# Altium schematic sheet 09-STM32-02.SchDoc(09-STM32-02)
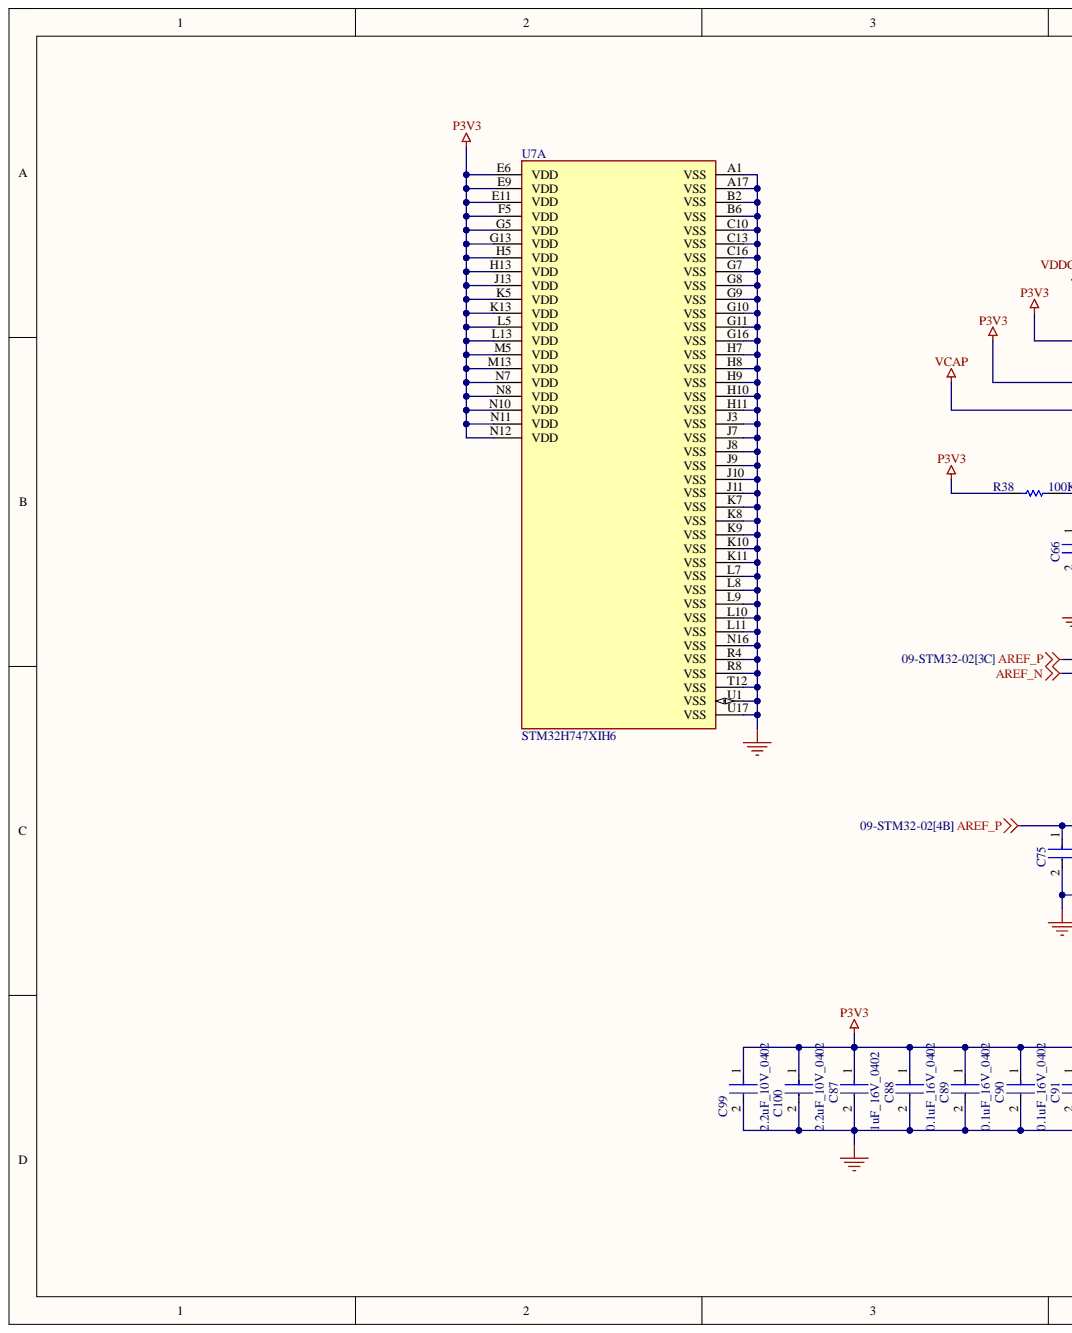
[diagram p0: 09-STM32-02.SchDoc, left]
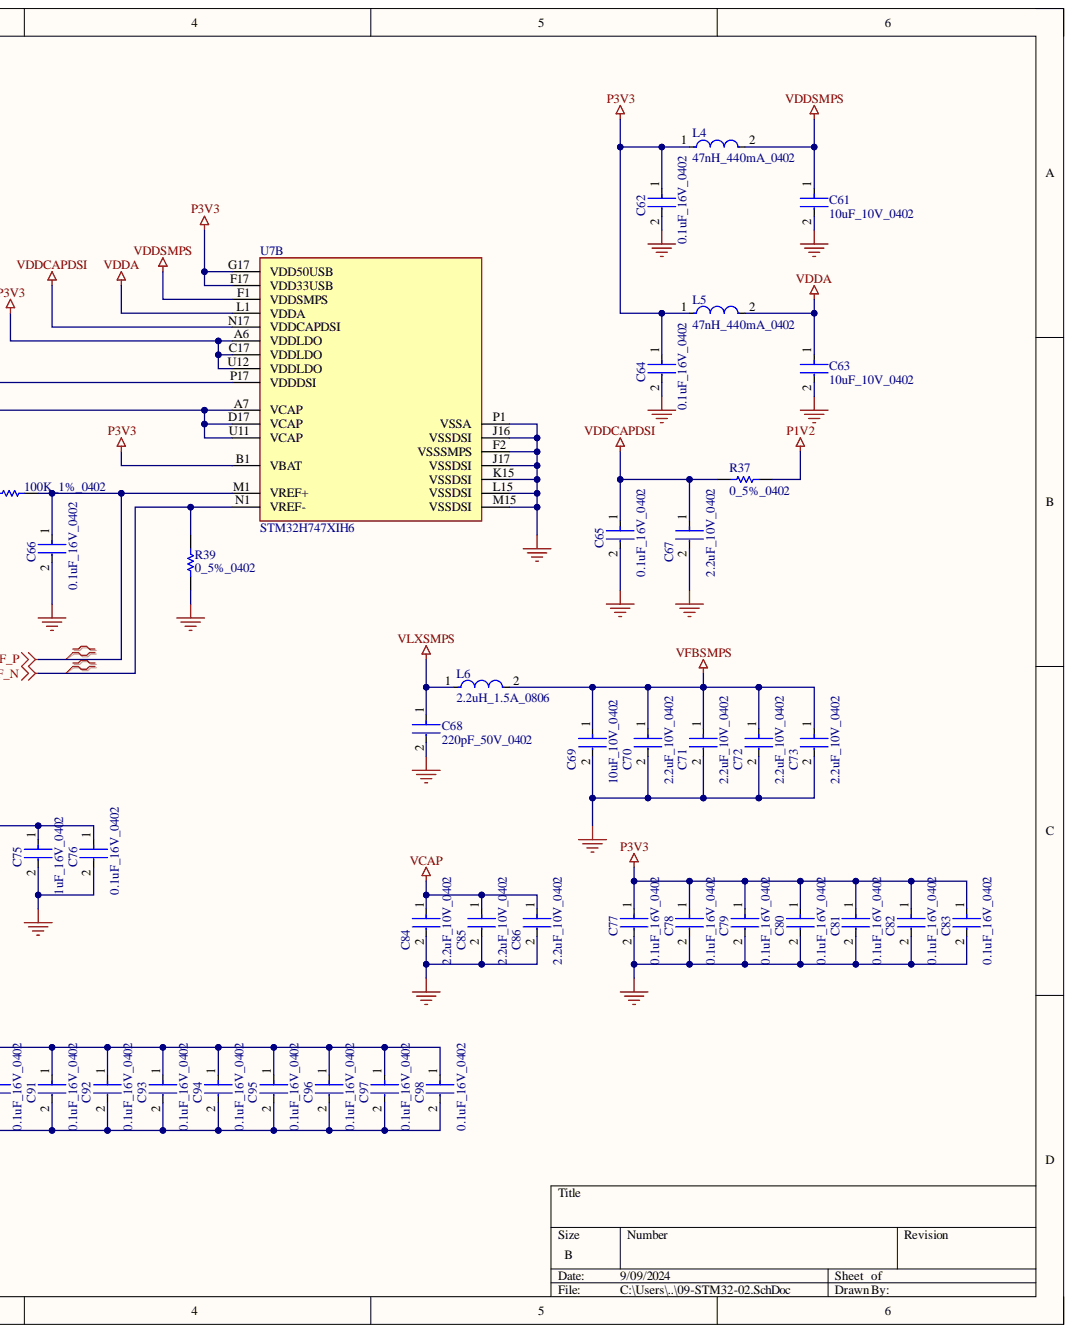
[diagram p1: 09-STM32-02.SchDoc, right]

## Parts
C61  10uF_10V_0402  [FP-CL05A106MP5NUNC-MFG]
C62  0.1uF_16V_0402  [FP-C0402-BB-MFG]
C63  10uF_10V_0402  [FP-CL05A106MP5NUNC-MFG]
C64  0.1uF_16V_0402  [FP-C0402-BB-MFG]
C65  0.1uF_16V_0402  [FP-C0402-BB-MFG]
C66  0.1uF_16V_0402  [FP-C0402-BB-MFG]
C67  2.2uF_10V_0402  [FP-C1005-050-0_15-0_1-MFG]
C68  220pF_50V_0402  [FP-GCM155-0_05-IPC_C]
C69  10uF_10V_0402  [FP-CL05A106MP5NUNC-MFG]
C70  2.2uF_10V_0402  [FP-C1005-050-0_15-0_1-MFG]
C71  2.2uF_10V_0402  [FP-C1005-050-0_15-0_1-MFG]
C72  2.2uF_10V_0402  [FP-C1005-050-0_15-0_1-MFG]
C73  2.2uF_10V_0402  [FP-C1005-050-0_15-0_1-MFG]
C75  1uF_16V_0402  [FP-0402-L_1_0_0_05-W_0_5-IPC_A]
C76  0.1uF_16V_0402  [FP-C0402-BB-MFG]
C77  0.1uF_16V_0402  [FP-C0402-BB-MFG]
C78  0.1uF_16V_0402  [FP-C0402-BB-MFG]
C79  0.1uF_16V_0402  [FP-C0402-BB-MFG]
C80  0.1uF_16V_0402  [FP-C0402-BB-MFG]
C81  0.1uF_16V_0402  [FP-C0402-BB-MFG]
C82  0.1uF_16V_0402  [FP-C0402-BB-MFG]
C83  0.1uF_16V_0402  [FP-C0402-BB-MFG]
C84  2.2uF_10V_0402  [FP-C1005-050-0_15-0_1-MFG]
C85  2.2uF_10V_0402  [FP-C1005-050-0_15-0_1-MFG]
C86  2.2uF_10V_0402  [FP-C1005-050-0_15-0_1-MFG]
C87  1uF_16V_0402  [FP-0402-L_1_0_0_05-W_0_5-IPC_A]
C88  0.1uF_16V_0402  [FP-C0402-BB-MFG]
C89  0.1uF_16V_0402  [FP-C0402-BB-MFG]
C90  0.1uF_16V_0402  [FP-C0402-BB-MFG]
C91  0.1uF_16V_0402  [FP-C0402-BB-MFG]
C92  0.1uF_16V_0402  [FP-C0402-BB-MFG]
C93  0.1uF_16V_0402  [FP-C0402-BB-MFG]
C94  0.1uF_16V_0402  [FP-C0402-BB-MFG]
C95  0.1uF_16V_0402  [FP-C0402-BB-MFG]
C96  0.1uF_16V_0402  [FP-C0402-BB-MFG]
C97  0.1uF_16V_0402  [FP-C0402-BB-MFG]
C98  0.1uF_16V_0402  [FP-C0402-BB-MFG]
C99  2.2uF_10V_0402  [FP-C1005-050-0_15-0_1-MFG]
C100  2.2uF_10V_0402  [FP-C1005-050-0_15-0_1-MFG]
L4  47nH_440mA_0402  [FP-LQW15AN_80-MFG]
L5  47nH_440mA_0402  [FP-LQW15AN_80-MFG]
L6  2.2uH_1.5A_0806  [FP-DFE201612C-MFG]
R37  0_5%_0402  [RESC1005X40X25NL05T10]
R38  100K_1%_0402  [RESC1005X40X25ML05T10]
R39  0_5%_0402  [RESC1005X40X25NL05T10]
U7  STM32H747XIH6  [FP-TFBGA240+25-A07U-MFG]  (parts A,B)

## Nets
AREF_N: R39.2 U7.N1(VREF-)
AREF_P: C66.1 C75.1 C76.1 R38.2 U7.M1(VREF+)
GND: C61.2 C62.2 C63.2 C64.2 C65.2 C66.2 C67.2 C68.2 C69.2 C70.2 C71.2 C72.2 C73.2 C75.2 C76.2 C77.2 C78.2 C79.2 C80.2 C81.2 C82.2 C83.2 C84.2 C85.2 C86.2 C87.2 C88.2 C89.2 C90.2 C91.2 C92.2 C93.2 C94.2 C95.2 C96.2 C97.2 C98.2 C99.2 C100.2 R39.1 U7.A1(VSS) U7.A17(VSS) U7.B2(VSS) U7.B6(VSS) U7.C10(VSS) U7.C13(VSS) U7.C16(VSS) U7.F2(VSSSMPS) U7.G7(VSS) U7.G8(VSS) U7.G9(VSS) U7.G10(VSS) U7.G11(VSS) U7.G16(VSS) U7.H7(VSS) U7.H8(VSS) U7.H9(VSS) U7.H10(VSS) U7.H11(VSS) U7.J3(VSS) U7.J7(VSS) U7.J8(VSS) U7.J9(VSS) U7.J10(VSS) U7.J11(VSS) U7.J16(VSSDSI) U7.J17(VSSDSI) U7.K7(VSS) U7.K8(VSS) U7.K9(VSS) U7.K10(VSS) U7.K11(VSS) U7.K15(VSSDSI) U7.L7(VSS) U7.L8(VSS) U7.L9(VSS) U7.L10(VSS) U7.L11(VSS) U7.L15(VSSDSI) U7.M15(VSSDSI) U7.N16(VSS) U7.P1(VSSA) U7.R4(VSS) U7.R8(VSS) U7.T12(VSS) U7.U1(VSS) U7.U17(VSS)
P1V2: R37.1
P3V3: C62.1 C64.1 C77.1 C78.1 C79.1 C80.1 C81.1 C82.1 C83.1 C87.1 C88.1 C89.1 C90.1 C91.1 C92.1 C93.1 C94.1 C95.1 C96.1 C97.1 C98.1 C99.1 C100.1 L4.1 L5.1 R38.1 U7.A6(VDDLDO) U7.B1(VBAT) U7.C17(VDDLDO) U7.E6(VDD) U7.E9(VDD) U7.E11(VDD) U7.F5(VDD) U7.F17(VDD33USB) U7.G5(VDD) U7.G13(VDD) U7.G17(VDD50USB) U7.H5(VDD) U7.H13(VDD) U7.J13(VDD) U7.K5(VDD) U7.K13(VDD) U7.L5(VDD) U7.L13(VDD) U7.M5(VDD) U7.M13(VDD) U7.N7(VDD) U7.N8(VDD) U7.N10(VDD) U7.N11(VDD) U7.N12(VDD) U7.P17(VDDDSI) U7.U12(VDDLDO)
VCAP: C84.1 C85.1 C86.1 U7.A7(VCAP) U7.D17(VCAP) U7.U11(VCAP)
VDDA: C63.1 L5.2 U7.L1(VDDA)
VDDCAPDSI: C65.1 C67.1 R37.2 U7.N17(VDDCAPDSI)
VDDSMPS: C61.1 L4.2 U7.F1(VDDSMPS)
VFBSMPS: C69.1 C70.1 C71.1 C72.1 C73.1 L6.2
VLXSMPS: C68.1 L6.1

## Library data of the parts
C61 C63 C69 = CMP-13271-000002-1: CAP CER 10UF 10V X5R 0402; Manufacturer: Samsung; Manufacturer Part Number: CL05A106MP5NUNC; Material: Ceramic; Temperature Coefficient: 15%; Tolerance: 20%; Automotive: No; Capacitance: 10uF; Category: Cap; Component Kind: Standard; ComponentLink2Description: Datasheet; ComponentLink2URL: http://www.samsungsem.com/kr/support/product-search/mlcc/__icsFiles/afieldfile/2019/05/10/19-CL05A106MP5NUNC.pdf; Device Class L1: Passive Components; Device Class L2: Capacitors; Device Class L3: Ceramic Capacitors; Digikey Description: CAP CER 10UF 10V X5R 0402; Height: 0.7mm; Ipc Land Pattern Name: CAPC10050X50; Lead Free: Yes; Library Name: Altium Content Vault; Octopart: https://octopart.com/cl05a106mp5nunc-samsung-22457395; Package: CL05A106MP5NUNC; RoHS: TRUE; Temperature: -55°C to +85°C; Temperature Characteristic: X5R; Temperature Range High: +85°C; Temperature Range Low: -55°C; Value: 10uF; Voltage Rating: 10V
C62 C64-C66 C76-C83 C88-C98 = CMP-1034-02068-3: CAP CER 0.1UF 16V 5% X7R 0402; Manufacturer: Kemet; Manufacturer Part Number: C0402C104J4RACTU; Capacitance: 0.10 μF; Material: Ceramic; Temperature Coefficient: 15%; Tolerance: 0.05; Value: 0.10 μF; Automotive: No; Category: Cap; Component Kind: Standard; ComponentLink2Description: Datasheet; ComponentLink2URL: https://content.kemet.com/datasheets/KEM_C1002_X7R_SMD.pdf; Device Class L1: Passive Components; Device Class L2: Capacitors; Device Class L3: Ceramic Capacitors; Digikey Description: CAP CER 0.1UF 16V 5% X7R 0402; Height: 0.55mm; Ipc Land Pattern Name: CAPC10050X50; Lead Free: Yes; Library Name: Altium Content Vault; Mouser Description: CAP CER 0.1UF 16V X7R 0402; Octopart: https://octopart.com/c0402c104j4ractu-kemet-12573893; Package: C0402-BB; RoHS: TRUE; Temperature: -55°C to +125°C; Temperature Characteristic: X7R; Temperature Range High: +125°C; Temperature Range Low: -55°C; Voltage Rating: 16 V
C67 C70-C73 C84-C86 C99 C100 = CMP-08246-004344-1: CAP CER 2.2UF 10V X7S 0402; Dielectric Material: Ceramic; Tolerance: 10%; Capacitance: 2.2uF; Case Code (Imperial): 0402; Case Code (Metric): 1005; Case/Package: 0402; Component Kind: Standard; Depth: 0.5mm; Dielectric: X7S; Height: 0.5mm; Lead Free: Lead Free; Length: 1mm; Library Name: Altium Content Vault; Max Operating Temperature: 125°C; Min Operating Temperature: -55°C; Mount: Surface Mount; Package Quantity: 1; Packaging: Tape and Reel; Resistor Type: Ceramic; RoHS Compliant: Yes; Series: C; Termination: SMD/SMT; Thickness: 0.022inch; Voltage Rating: 10V; Voltage Rating (DC): 10V; Weight: 2.3E-05oz; Width: 0.02inch
C68 = CMP-2008-03726-2: Ceramic Capacitor for Automotive 220pF ±10% 50VDC X7R 0402 Paper T/R; Voltage: 50V; Capacitance: 220pF; Case Code (Imperial): 0402; Case Code (Metric): 1005; Case/Package: 0402; Component Kind: Standard; Depth: 0.5mm; Dielectric: X7R; Height: 0.5mm; Length: 1mm; Library Name: Altium Content Vault; Max Operating Temperature: 125°C; Min Operating Temperature: -55°C; Mount: Surface Mount; Package Quantity: 1; Packaging: Tape and Reel; Radiation Hardening: No; Ratings: AEC-Q200; Termination: SMD/SMT; Thickness: 0.022inch; Tolerance: 10%; Voltage Rating: 50V; Voltage Rating (DC): 50V; Width: 0.02inch
C75 C87 = CMP-14477-000104-2: None; Capacitance: 1uF; Dielectric: X5R; Tolerance: 10%; Voltage Rating: 16V; Case Code (Imperial): 0402; Case Code (Metric): 1005; Case/Package: 0402; Component Kind: Standard; Construction: Flat; Depth: 0.5mm; Halogen Free: Halogen Free; Height: 0.5mm; Length: 1mm; Library Name: Altium Content Vault; Max Operating Temperature: 85°C; Min Operating Temperature: -55°C; Mount: Surface Mount; Number of Pins: 2; Package Quantity: 1; Packaging: Tape and Reel; Radiation Hardening: No; RoHS Compliant: Yes; Series: M; Termination: SMD/SMT; Thickness: 0.022inch; Voltage Rating (DC): 16V; Width: 0.02inch
L4 L5 = CMP-06042-005115-1: Wire Wound RF Inductor 47nH ±5% 440mA 0.648Ω 0402 (1005); Core Material: Non-Magnetic; DC Resistance (DCR): 648mR; Q Factor: 25; Self Resonant Frequency: 3.2GHz; Shielding: Unshielded; Test Frequency: 100MHz; Case Code (Imperial): 0402; Case Code (Metric): 1005; Case/Package: 0402; Component Kind: Standard; Current Rating: 440mA; Height: 0.5mm; Height - Seated (Max): 0.024inch; Inductance: 47nH; Length: 0.039inch; Library Name: Altium Content Vault; Max DC Current: 440mA; Max Operating Temperature: 125°C; Min Operating Temperature: -55°C; Mount: Surface Mount; Package Quantity: 1; Packaging: Tape and Reel; Resistor Type: Wirewound; Termination: SMD/SMT; Tolerance: 5%; Width: 0.024inch
L6 = CMP-06042-007475-1: Shielded Wirewound Inductor 2.2uH 20% 1500mA 0.144Ω 0806 (2016); Core Material: Ferrite; Current Rating: 2.1A; DC Resistance (DCR): 144mR; Max DC Current: 1.5A; Test Frequency: 1MHz; Case Code (Imperial): 0806; Case Code (Metric): 2016; Case/Package: 0806; Component Kind: Standard; Depth: 1.6mm; Height - Seated (Max): 0.039inch; Inductance: 2.2uH; Length: 0.079inch; Library Name: Altium Content Vault; Max Operating Temperature: 125°C; Min Operating Temperature: -40°C; Mount: Surface Mount; Packaging: Tape and Reel; Resistor Type: Wirewound; Shielding: Shielded; Tolerance: 20%; Width: 0.063inch
R37 R39 = CMP-2002-06457-1: Manufacturer: Panasonic; Part Number: ERJ-U020R00X; Component Kind: Standard; Library Name: Altium Content Vault
R38 = CMP-2002-07852-1: Manufacturer: Yageo; Part Number: RC0402FR-13100KL; Component Kind: Standard; Library Name: Altium Content Vault
U7 = CMP-12105-000055-1: HIGH-PERFORMANCE AND DSP WITH DP; Manufacturer: STMicroelectronics; Core Architecture: ARM Cortex-M4, ARM Cortex-M7; Data Bus Width: 32bits; Frequency: 480MHz; Interface: I2C,SPI,UART,USART,CAN,USB,LIN,IrDA,Ethernet,I2S,SMBus,Other; Max Junction Temp: +125°C; Max Supply Voltage: 3.6V; Memory Size: 2MBytes; Memory Type: FLASH; Min Supply Voltage: 1.2V; Number Of A/D Converters: 3; Number Of Adc Channels: 36; Number Of Cores: 2; Number Of Ethernet Channels: 1; Number Of I2C Channels: 4; Number Of I/Os: 168; Number Of Spi Channels: 6; Number Of Timers/Counters: 22; Number Of Uart Channels: 9; Ram Size: 1MBytes; Standoff Height: 0.15mm; Ambient Temperature Range High: +85°C; Ambient Temperature Range Low: -40°C; Automotive: No; Category: IC; Component Kind: Standard; ComponentLink2Description: Datasheet; ComponentLink2URL: https://www.st.com/resource/en/datasheet/stm32h747xi.pdf; Device Class L1: Integrated Circuits (ICs); Device Class L2: Embedded Processors and Controllers; Device Class L3: Microcontrollers; Digikey Description: HIGH-PERFORMANCE AND DSP WITH DP; Height: 1.1mm; Ipc Land Pattern Name: BGA289N80P17X17_1400X1400X110; Lead Free: Yes; Library Name: Altium Content Vault; Manufacturer Part Number: STM32H747XIH6; Mouser Description: STM32 Series 2 MB Flash 1 MB RAM 480 MHz 32-Bit Microcontroller - TFBGA-265; Number Of Pwm Channels: 2; Number Of Usb Channels: 2; Octopart: https://octopart.com/stm32h747xih6-stmicroelectronics-100293943; Package: TFBGA240+25-A07U; RoHS: TRUE; Temperature: -40°C to +125°C; Temperature Range High: +125°C; Temperature Range Low: -40°C
